# Altium schematic sheet MainPCB.SchDoc(MainPCB)
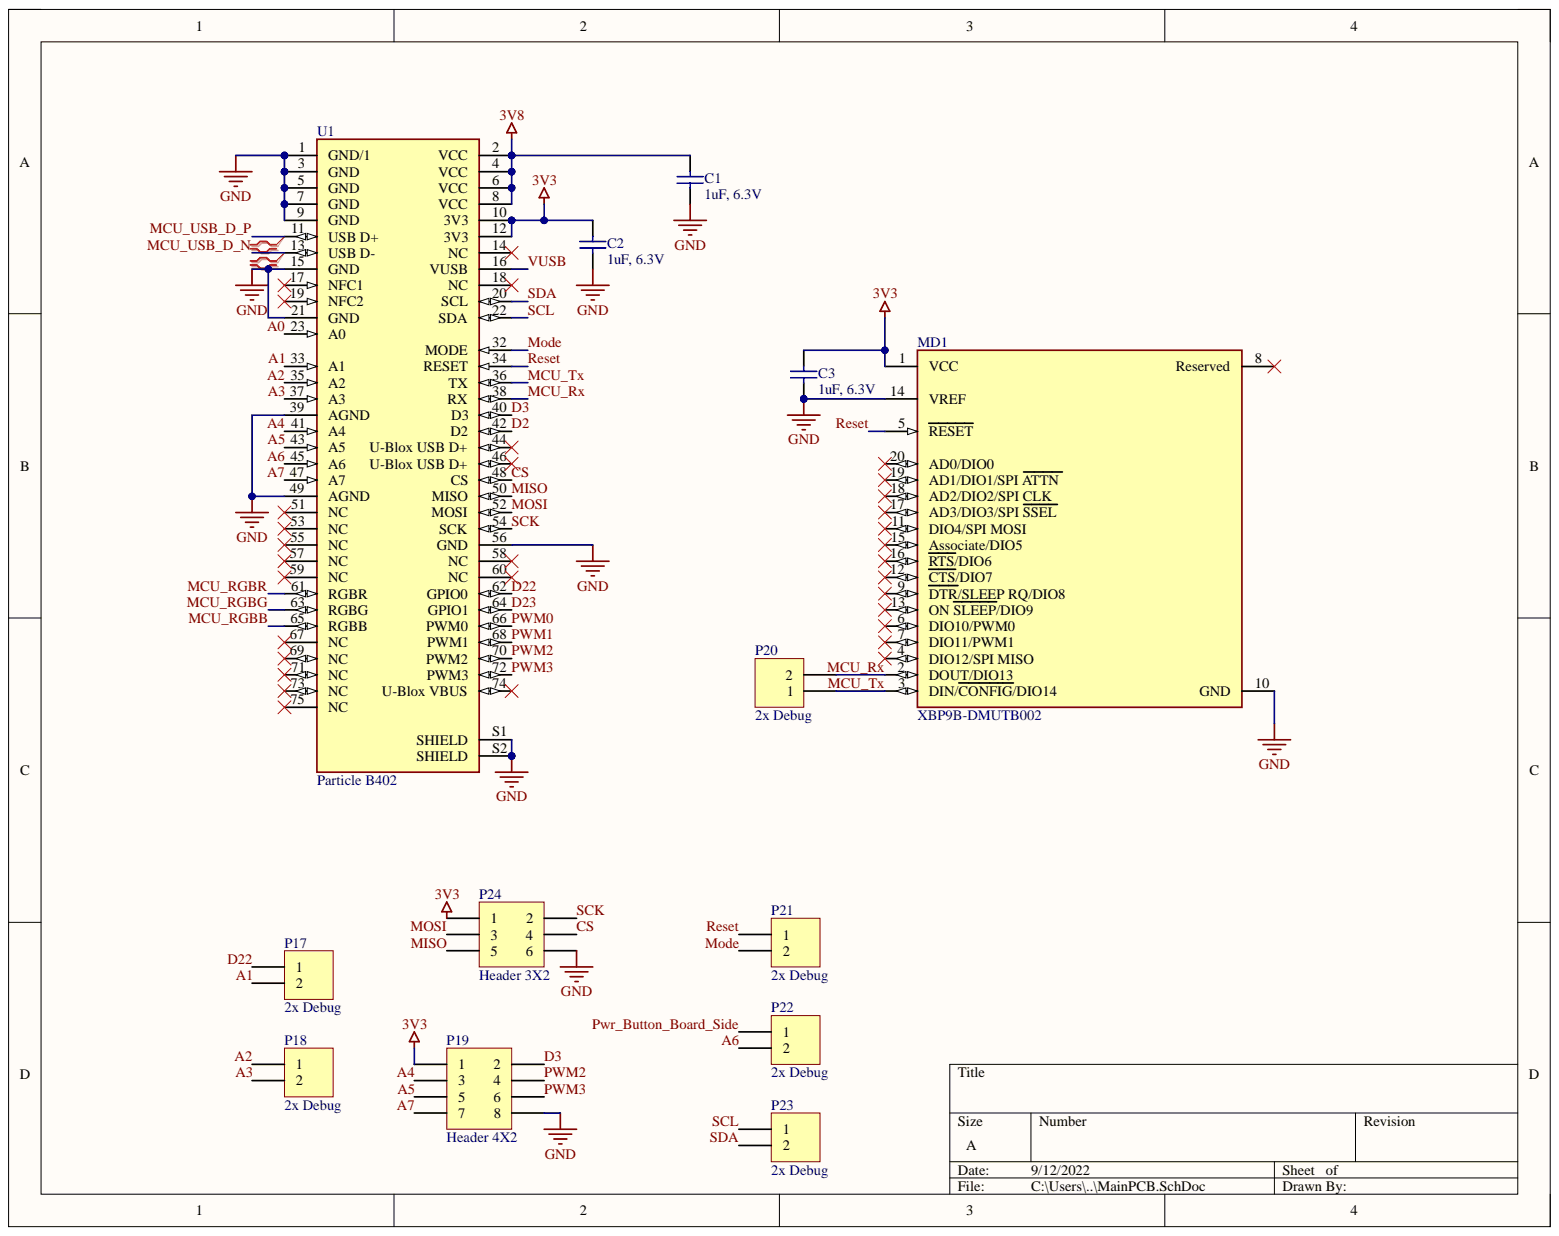
[diagram p0: MainPCB.SchDoc]

## Parts
C1  value 1uF, 6.3V  [ANTC1608X90N]  (hidden comment: Capacitor)
C2  value 1uF, 6.3V  [ANTC1608X90N]  (hidden comment: Capacitor)
C3  value 1uF, 6.3V  [ANTC1608X90N]  (hidden comment: Capacitor)
MD1  XBP9B-DMUTB002  [FP-XBP9B-DMUTB002-MFG]
P17  2x Debug  [1x2 Header]
P18  2x Debug  [1x2 Header]
P19  Header 4X2  [4x2 Header]
P20  2x Debug  [1x2 Header]
P21  2x Debug  [1x2 Header]
P22  2x Debug  [1x2 Header]
P23  2x Debug  [1x2 Header]
P24  Header 3X2  [2x3 Header]
U1  B402  Supplier Part Number 1: Particle B402  [B402 Full]

## Nets
3V3: C2.2 C3.2 MD1.1(VCC) P19.1 P24.1 U1.10(3V3) U1.12(3V3)
3V8: C1.2 U1.2(VCC) U1.4(VCC) U1.6(VCC) U1.8(VCC)
GND: C1.1 C2.1 C3.1 MD1.10(GND) MD1.14(VREF) P19.8 P24.6 U1.1(GND/1) U1.3(GND) U1.5(GND) U1.7(GND) U1.9(GND) U1.15(GND) U1.21(GND) U1.39(AGND) U1.49(AGND) U1.56(GND) U1.S1(SHIELD) U1.S2(SHIELD)
A0: U1.23(A0)
A1: P17.2 U1.33(A1)
A2: P18.1 U1.35(A2)
A3: P18.2 U1.37(A3)
A4: P19.3 U1.41(A4)
A5: P19.5 U1.43(A5)
A6: P22.2 U1.45(A6)
A7: P19.7 U1.47(A7)
CS: P24.4 U1.48(CS)
D2: U1.42(D2)
D3: P19.2 U1.40(D3)
D22: P17.1 U1.62(GPIO0)
D23: U1.64(GPIO1)
MCU_RGBB: U1.65(RGBB)
MCU_RGBG: U1.63(RGBG)
MCU_RGBR: U1.61(RGBR)
MCU_Rx: MD1.2(DOUT/DIO13) P20.2 U1.38(RX)
MCU_Tx: MD1.3(DIN/CONFIG/DIO14) P20.1 U1.36(TX)
MCU_USB_D_N: U1.13(USB_D-)
MCU_USB_D_P: U1.11(USB_D+)
MISO: P24.5 U1.50(MISO)
Mode: P21.2 U1.32(MODE)
MOSI: P24.3 U1.52(MOSI)
PWM0: U1.66(PWM0)
PWM1: U1.68(PWM1)
PWM2: P19.4 U1.70(PWM2)
PWM3: P19.6 U1.72(PWM3)
Pwr_Button_Board_Side: P22.1
Reset: MD1.5(RESET) P21.1 U1.34(RESET)
SCK: P24.2 U1.54(SCK)
SCL: P23.1 U1.22(SDA)
SDA: P23.2 U1.20(SCL)
VUSB: U1.16(VUSB)

Not connected: MD1 4(DIO12/SPI_MISO) 6(DIO10/PWM0) 7(DIO11/PWM1) 8(Reserved) 9(DTR/SLEEP_RQ/DIO8) 11(DIO4/SPI_MOSI) 12(CTS/DIO7) 13(ON_SLEEP/DIO9) 15(Associate/DIO5) 16(RTS/DIO6) 17(AD3/DIO3/SPI_SSEL) 18(AD2/DIO2/SPI_CLK) 19(AD1/DIO1/SPI_ATTN) 20(AD0/DIO0); U1 14(NC) 17(NFC1) 18(NC) 19(NFC2) 44 46 51(NC) 53(NC) 55(NC) 57(NC) 58(NC) 59(NC) 60(NC) 67(NC) 69(NC) 71(NC) 73(NC) 74(U-Blox_VBUS) 75(NC)

## Library data of the parts
C1 C2 = Capacitor: SimulationGenericComponent: True; Component Kind: Standard; Description: Capacitor; Library Name: Simulation Generic Components.IntLib; Simulation: C_Capacitor_Default
C3 = Capacitor: SimulationGenericComponent: True; Value: 1uF, 6.3V; Component Kind: Standard; Description: Capacitor; Library Name: Simulation Generic Components.IntLib; Simulation: C_Capacitor_Default
MD1 = CMP-84319-000004-1: RX TXRX MOD ISM < 1GHZ U.FL TH; Current - Receiving: 29mA; Current - Transmitting: 215mA; Data Rate: 200Kbps; Frequency: 900MHz; Interface: SPI; Max Frequency: 928MHz; Max Supply Voltage: 3.6V; Memory Size: 2KB; Min Frequency: 902MHz; Min Supply Voltage: 2.4V; Output Power (dBm): 24dBm; Sensitivity: -101dBm; Case/Package: Module; Component Kind: Standard; Library Name: Altium Content Vault; Max Operating Temperature: 85°C; Min Operating Temperature: -40°C; Number of Pins: 20; Package Quantity: 1; Packaging: Bulk; Radiation Hardening: No; RAM Size: 2KB; Series: XBee-Pro™
P17 P18 P20-P23 = Header 2: Header, 2-Pin; Component Kind: Standard; LatestRevisionDate: 17-Jul-2002; LatestRevisionNote: Re-released for DXP Platform.; Library Name: Miscellaneous Connectors.IntLib; Signal Integrity: Connector; Publisher: Altium Limited
P19 = Header 4X2: Header, 4-Pin, Dual row; Component Kind: Standard; LatestRevisionDate: 17-Jul-2002; LatestRevisionNote: Re-released for DXP Platform.; Library Name: Miscellaneous Connectors.IntLib; Signal Integrity: Connector; Publisher: Altium Limited
P24 = Header 3X2: Header, 3-Pin, Dual row; Component Kind: Standard; LatestRevisionDate: 17-Jul-2002; LatestRevisionNote: Re-released for DXP Platform.; Library Name: Miscellaneous Connectors.IntLib; Signal Integrity: Connector; Publisher: Altium Limited
U1 = B402: Connector, Backplane, Housing, Receptacle, 67 Position, 2row; MP: 2199230-4; Availability: Unavailable; Component Kind: Standard; Library Name: WaterNet23BotPCB.SCHLIB; Package: None; Price: None; Supplier 1: Digi-Key
